# Altium schematic sheet TeensyLiteLoggerV2.SchDoc(TeensyLiteLoggerV2)
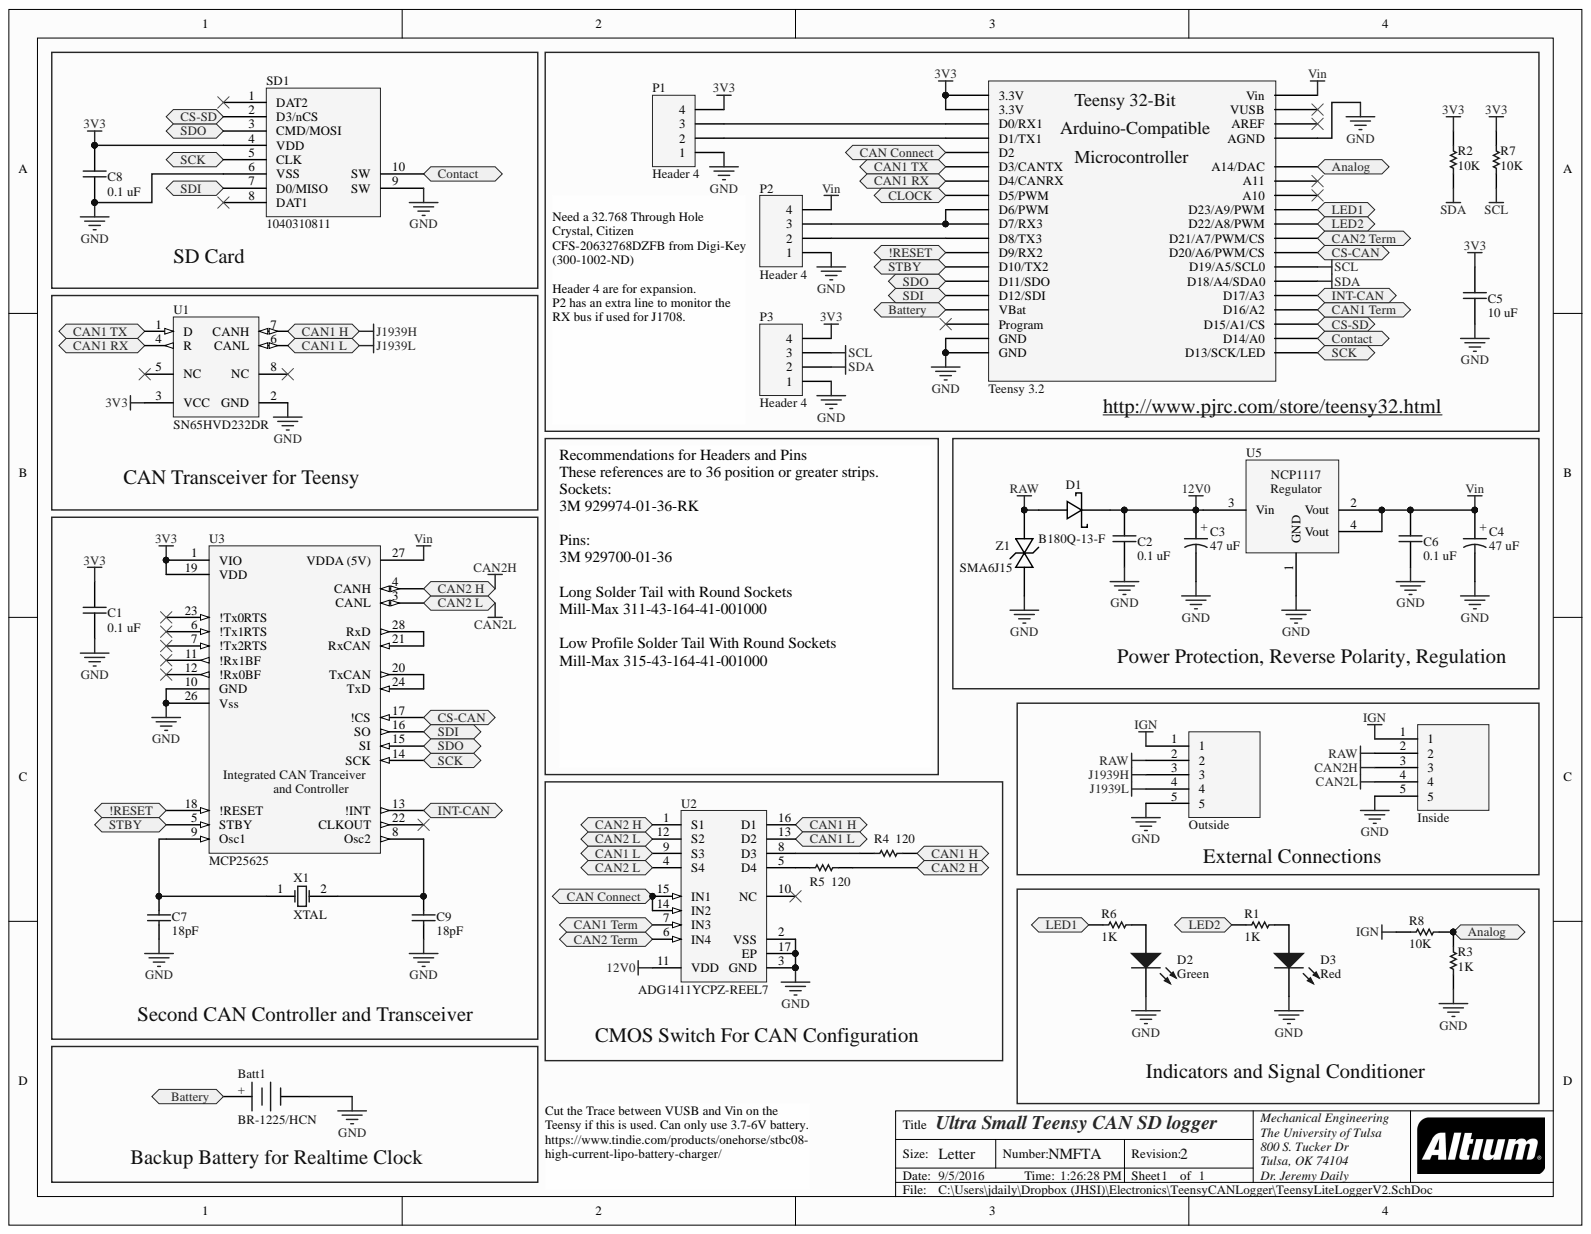
[diagram p0: TeensyLiteLoggerV2.SchDoc]

## Parts
Batt1  BR-1225/HCN  [BR-1225/HCN Coin Cell]
BRD1  Teensy 3.2  [Teensy]
C1  0.1 uF  [0603]
C2  0.1 uF  [0603]
C3  47 uF  [2917]
C4  47 uF  [2917]
C5  10 uF  [0603]
C6  0.1 uF  [0603]
C7  18pF  [0603]
C8  0.1 uF  [0603]
C9  18pF  [0603]
D1  B180Q-13-F  [SMA with A and K]
D2  Green  [0805 Polarized]
D3  Red  [0805 Polarized]
J6  Outside  [5 POS Vert MINI-FIT JR]
J7  Inside  [5 POS Vert MINI-FIT JR]
P1  Header 4  [HDR1X4]
P2  Header 4  [HDR1X4]
P3  Header 4  [HDR1X4]
R1  1K  [0603]
R2  10K  [0603]
R3  1K  [0603]
R4  120  [0603]
R5  120  [0603]
R6  1K  [0603]
R7  10K  [0603]
R8  10K  [0603]
SD1  1040310811  [SD Holder Push-pull]
U1  SN65HVD232DR  [SN65HVD232]
U2  ADG1411YCPZ-REEL7  [ADI-CP-16-13_N]
U3  MCP25625  [SOP65P780X200-28N]
U5  LDO1117  [SOT-223]  (value not drawn)
X1  XTAL  [XTAL 2 pad]
Z1  SMA6J15  [SMA]

## Ports
!RESET, ANALOG, BATTERY, CAN1 H, CAN1 L, CAN1 RX, CAN1 TERM, CAN1 TX, CAN2 H, CAN2 L, CAN2 TERM, CAN CONNECT, CLOCK, CONTACT, CS-CAN, CS-SD, INT-CAN, LED1, LED2, SCK, SDI, SDO, STBY

## Nets
3V3: BRD1.16(3.3V) BRD1.31(3.3V) C1.2 C5.2 C8.2 P1.4 P3.4 R2.1 R7.1 SD1.4(VDD) U1.3(VCC) U3.1(VIO) U3.19(VDD)
12V0: C2.2 C3.1 D1.2 U2.11(VDD) U5.3(Vin)
GND: Batt1.2 BRD1.14(GND) BRD1.17(GND) BRD1.32(AGND) C1.1 C2.1 C3.2 C4.2 C5.1 C6.1 C7.2 C8.1 C9.1 D2.2 D3.2 J6.5 J7.5 P1.1 P2.1 P3.1 R3.2 SD1.6(VSS) SD1.9(SW) U1.2(GND) U2.2(VSS) U2.3(GND) U2.17(EP) U3.10(GND) U3.26(Vss) U5.1(GND) Z1.1
IGN: J6.1 J7.1 R8.1
RAW: D1.1 J6.2 J7.2 Z1.2
SCL: BRD1.26(D19/A5/SCL0) P3.3 R7.2
SDA: BRD1.25(D18/A4/SDA0) P3.2 R2.2
VIN: BRD1.33(Vin) C4.1 C6.2 P2.4 U3.27(VDDA_(5V)) U5.2(Vout) U5.4(Vout)
!RESET: BRD1.4(D9/RX2) U3.18(!RESET) ; port !RESET
CAN1 RX: BRD1.9(D4/CANRX) U1.4(R) ; port CAN1 RX
CAN1 TX: BRD1.10(D3/CANTX) U1.1(D) ; port CAN1 TX
CAN2H: J7.3 R5.1 U2.1(S1) U3.4(CANH) ; port CAN2 H
CAN2L: J7.4 U2.4(S4) U2.12(S2) U3.3(CANL) ; port CAN2 L
CLOCK: BRD1.8(D5/PWM) ; port CLOCK
CS-CAN: BRD1.27(D20/A6/PWM/CS) U3.17(!CS) ; port CS-CAN
CS-SD: BRD1.22(D15/A1/CS) SD1.2(D3/nCS) ; port CS-SD
INT-CAN: BRD1.24(D17/A3) U3.13(!INT) ; port INT-CAN
J1939H: J6.3 R4.1 U1.7(CANH) U2.16(D1) ; port CAN1 H
J1939L: J6.4 U1.6(CANL) U2.9(S3) U2.13(D2) ; port CAN1 L
LED1: BRD1.30(D23/A9/PWM) R6.2 ; port LED1
LED2: BRD1.29(D22/A8/PWM) R1.2 ; port LED2
SCK: BRD1.20(D13/SCK/LED) SD1.5(CLK) U3.14(SCK) ; port SCK
SDI: BRD1.1(D12/SDI) SD1.7(D0/MISO) U3.16(SO) ; port SDI
SDO: BRD1.2(D11/SDO) SD1.3(CMD/MOSI) U3.15(SI) ; port SDO
STBY: BRD1.3(D10/TX2) U3.5(STBY) ; port STBY
NetBatt1_1: Batt1.1 BRD1.15(VBat) ; port BATTERY
NetBRD1_5: BRD1.5(D8/TX3) P2.2
NetBRD1_6: BRD1.6(D7/RX3) BRD1.7(D6/PWM) P2.3
NetBRD1_11: BRD1.11(D2) U2.14(IN2) U2.15(IN1) ; port CAN CONNECT
NetBRD1_12: BRD1.12(D1/TX1) P1.2
NetBRD1_13: BRD1.13(D0/RX1) P1.3
NetBRD1_19: BRD1.19(A14/DAC) R3.1 R8.2 ; port ANALOG
NetBRD1_21: BRD1.21(D14/A0) SD1.10(SW) ; port CONTACT
NetBRD1_23: BRD1.23(D16/A2) U2.7(IN3) ; port CAN1 TERM
NetBRD1_28: BRD1.28(D21/A7/PWM/CS) U2.6(IN4) ; port CAN2 TERM
NetC7_1: C7.1 U3.9(Osc1) X1.1
NetC9_2: C9.2 U3.8(Osc2) X1.2
NetD2_1: D2.1 R6.1
NetD3_1: D3.1 R1.1
NetR4_2: R4.2 U2.8(D3)
NetR5_2: R5.2 U2.5(D4)
NetU3_20: U3.20(TxCAN) U3.24(TxD)
NetU3_21: U3.21(RxCAN) U3.28(RxD)

Not connected: BRD1 18(Program) 34(VUSB) 35(AREF) 36(A10) 37(A11); SD1 1(DAT2) 8(DAT1); U1 5(NC) 8(NC); U2 10(NC); U3 6(!Tx1RTS) 7(!Tx2RTS) 11(!Rx1BF) 12(!Rx0BF) 22(CLKOUT) 23(!Tx0RTS)

## Library data of the parts
Batt1 = CR2032 Battery Holder: Lithium Battery Non-Rechargeable (Primary) 3V Coin, 12.5mm; Manufacturer: Panasonic; Component Kind: Standard; Library Name: SSS Schematic Library.SchLib; PartNumber: BR-1225/HCN; Supplier 1: Digi-Key; Supplier 2: Mouser; Supplier Part Number 1: P191-ND; Supplier Part Number 2: 658-BR-1225/HCN
BRD1 = Teensy: 32 Bit Arduino-Compatible Microcontroller Headers x 2 with 5 Pos; Manufacturer: 3M; PartNumber: 929700-01-36-RK; Component Kind: Standard; Library Name: SSS Integrated Library.IntLib; Supplier 1: Digi-Key; Supplier 2: Mouser; Supplier Part Number 1: 3M11971-ND; Supplier Part Number 2: 517-929700-01-36-RK
C1 C2 C6 C8 = Cap: CAP CER 0.1UF 50V X7R 0603; Manufacturer: Murata Electronics North America; PartNumber: GRM188R71H104KA93D; Component Kind: Standard; Library Name: SSS Integrated Library.IntLib; Supplier 1: Digi-Key; Supplier 2: Mouser; Supplier Part Number 1: 490-1519-1-ND; Supplier Part Number 2: 81-GRM39X104K50D
C3 C4 = Tantalum Cap_1: Tantalum Capacitors - Solid SMD 35volts 47uF 10% ESR=300mOhms; Manufacturer: Kemet; PartNumber: T495X476K035ATE300; Component Kind: Standard; Library Name: SSS Schematic Library.SchLib; Supplier 1: Digi-Key; Supplier 2: Mouser; Supplier Part Number 1: 399-3905-1-ND; Supplier Part Number 2: 80-T495X476K35ATE300
C5 = Cap: 10µF 6.3V Ceramic Capacitor X5R 0603 (1608 Metric) 0.063" L x 0.031" W (1.60mm x 0.80mm); Manufacturer: Murata Electronics North America; PartNumber: GRM188R60J106ME47D; Component Kind: Standard; Library Name: SSS Integrated Library.IntLib; Supplier 1: Digi-Key; Supplier 2: Mouser; Supplier Part Number 1: 490-3896-1-ND; Supplier Part Number 2: 81-GRM188R60J106ME47
C7 C9 = Cap: CAP CER 18PF 50V 5% NP0 0603; Manufacturer: Kemet; PartNumber: C0603C180J5GACTU; Component Kind: Standard; Library Name: SSS Schematic Library.SchLib; Signal Integrity: Cap; Simulation: CAP; Supplier 1: Digi-Key; Supplier 2: Mouser; Supplier Part Number 1: 399-1052-1-ND; Supplier Part Number 2: 80-C0603C180J5G
D1 = Schottky Diode: Diode Schottky 80V 1A Surface Mount SMA; Manufacturer: Diodes Incorporated; Component Kind: Standard; Library Name: SSS Integrated Library.IntLib; PartNumber: B180Q-13-F; Supplier 1: Digi-Key; Supplier 2: Mouser; Supplier Part Number 1: B180Q-13-FDICT-ND; Supplier Part Number 2: 621-B180Q-13-F
D2 = LED - Green: Green 570nm LED Indication - Discrete 2.2V 0805 (2012 Metric); Manufacturer: OSRAM Opto Semiconductors Inc.; PartNumber: LG R971-KN-1; Component Kind: Standard; Library Name: SSS Integrated Library.IntLib; Supplier 1: Digi-Key; Supplier 2: Mouser; Supplier Part Number 1: 475-1410-1-ND; Supplier Part Number 2: 720-LGR971-KN-1
D3 = LED - Red: Red 633nm LED Indication - Discrete 2V 0805 (2012 Metric); Manufacturer: OSRAM Opto Semiconductors Inc.; PartNumber: LS R976-NR-1; Component Kind: Standard; Library Name: SSS Integrated Library.IntLib; Supplier 1: Digi-Key; Supplier 2: Mouser; Supplier Part Number 1: 475-1278-1-ND; Supplier Part Number 2: 720-LSR976-NR-1
J6 J7 = 5 Pos Header Vert: Molex Mint Fit Jr 5 CKT VERT HEADER; Component Kind: Standard; Library Name: Molex Mini-Fit Jr.IntLib
P1-P3 = Header 4: Header, 4-Pin; Component Kind: Standard; Library Name: Miscellaneous Connectors.IntLib; Signal Integrity: Connector
R1 R3 R6 = Chip Resistor: RES SMD 1K OHM 1% 1/10W 0603; Manufacturer: Panasonic Electronic Components; PartNumber: ERJ-3EKF1001V; Component Kind: Standard; Library Name: SSS Integrated Library.IntLib; Supplier 1: Digi-Key; Supplier 2: Mouser; Supplier Part Number 1: P1.00KHCT-ND
R2 R7 R8 = Chip Resistor: RES SMD 10K OHM 1% 1/10W 0603; Manufacturer: Panasonic Electronic Components; PartNumber: ERJ-3EKF1002V; Component Kind: Standard; Library Name: SSS Integrated Library.IntLib; Supplier 1: Digi-Key; Supplier 2: Mouser; Supplier Part Number 1: P10.0KHCT-ND
R4 R5 = Chip Resistor: RES SMD 120 OHM 1% 1/10W 0603; Manufacturer: Panasonic Electronic Components; PartNumber: ERJ-3EKF1200V; Component Kind: Standard; Library Name: SSS Integrated Library.IntLib; Supplier 1: Digi-Key; Supplier 2: Mouser; Supplier Part Number 1: P120HCT-ND
SD1 = SD Card Holder: Molex 1040310811; Manufacturer: Molex, LLC; Component Kind: Standard; Library Name: Boards.SchLib; PartNumber: 1040310811; Supplier 1: Digi-Key; Supplier 2: Mouser; Supplier Part Number 1: WM6357CT-ND; Supplier Part Number 2: 538-104031-0811
U1 = SN65HVD232DG4: 1/1 Transceiver Half CAN 8-SOIC; Manufacturer: Texas Instruments; Component Kind: Standard; Library Name: Texas Instruments Interface CAN.IntLib; PartNumber: SN65HVD232DR; Supplier 1: Digi-Key; Supplier 2: Mouser; Supplier Part Number 1: 296-26344-1-ND; Supplier Part Number 2: 595-SN65HVD232DR
U2 = ADG1411YCPZ-REEL7: 1.5 Ohm on Resistance, +/-15 V / +12 V / +/-5 V, iCMOS, Quad SPST Switch, 16-pin QFN (CP-16-13), Reel; Manufacturer: Analog Devices; Component Kind: Standard; Library Name: Analog Devices Switches and Multiplexers Analog Switches.IntLib; PartNumber: ADG1411YCPZ-REEL7; Supplier 1: Digi-Key; Supplier 2: Mouser; Supplier Part Number 1: ADG1411YCPZ-REEL7TR-ND; Supplier Part Number 2: 584-ADG1411YCPZ-R7
U3 = MCP25625: CAN Interface IC CAN Controller with Int. Transceiver  28-SSOP; Manufacturer: Microchip; PartNumber: MCP25625-E/SS; Component Kind: Standard; Library Name: SSS Schematic Library.SchLib; Supplier 1: Digi-Key; Supplier 2: Mouser; Supplier Part Number 1: MCP25625-E/SS-ND; Supplier Part Number 2: 579-MCP25625-E/SS
U5 = NCP1117 2.5V: Linear Voltage Regulator IC Positive Fixed 1 Output 5V 1A SOT-223; Manufacturer: ON Semiconductor; PartNumber: NCV1117ST50T3G; Component Kind: Standard; Library Name: SSS Integrated Library.IntLib; Supplier 1: Digi-Key; Supplier 2: Mouser; Supplier Part Number 1: NCV1117ST50T3GOSCT-ND; Supplier Part Number 2: 863-NCV1117ST50T3G
X1 = Crystal Oscillator: CRYSTAL 16MHZ 18PF SMD; Manufacturer: TXC CORPORATION; PartNumber: 7A-16.000MAAJ-T; Component Kind: Standard; Library Name: SSS Schematic Library.SchLib; Supplier 1: Digi-Key; Supplier 2: Mouser; Supplier Part Number 1: 887-1086-1-ND; Supplier Part Number 2: 717-7A-16.000MAAJ-T
Z1 = Zener - Bidirectional: TVS DIODE 15VWM 24.4VC SMA; Manufacturer: Micro Commercial Co; PartNumber: SMA6J15CA-TP; Component Kind: Standard; Library Name: SSS Integrated Library.IntLib; Supplier 1: Digi-Key; Supplier 2: Mouser; Supplier Part Number 1: SMA6J15CA-TPMSCT-ND; Supplier Part Number 2: 833-SMA6J15CA-TP
